# Altium schematic sheet 02)Microcontroller.SchDoc(02)Microcontroller)
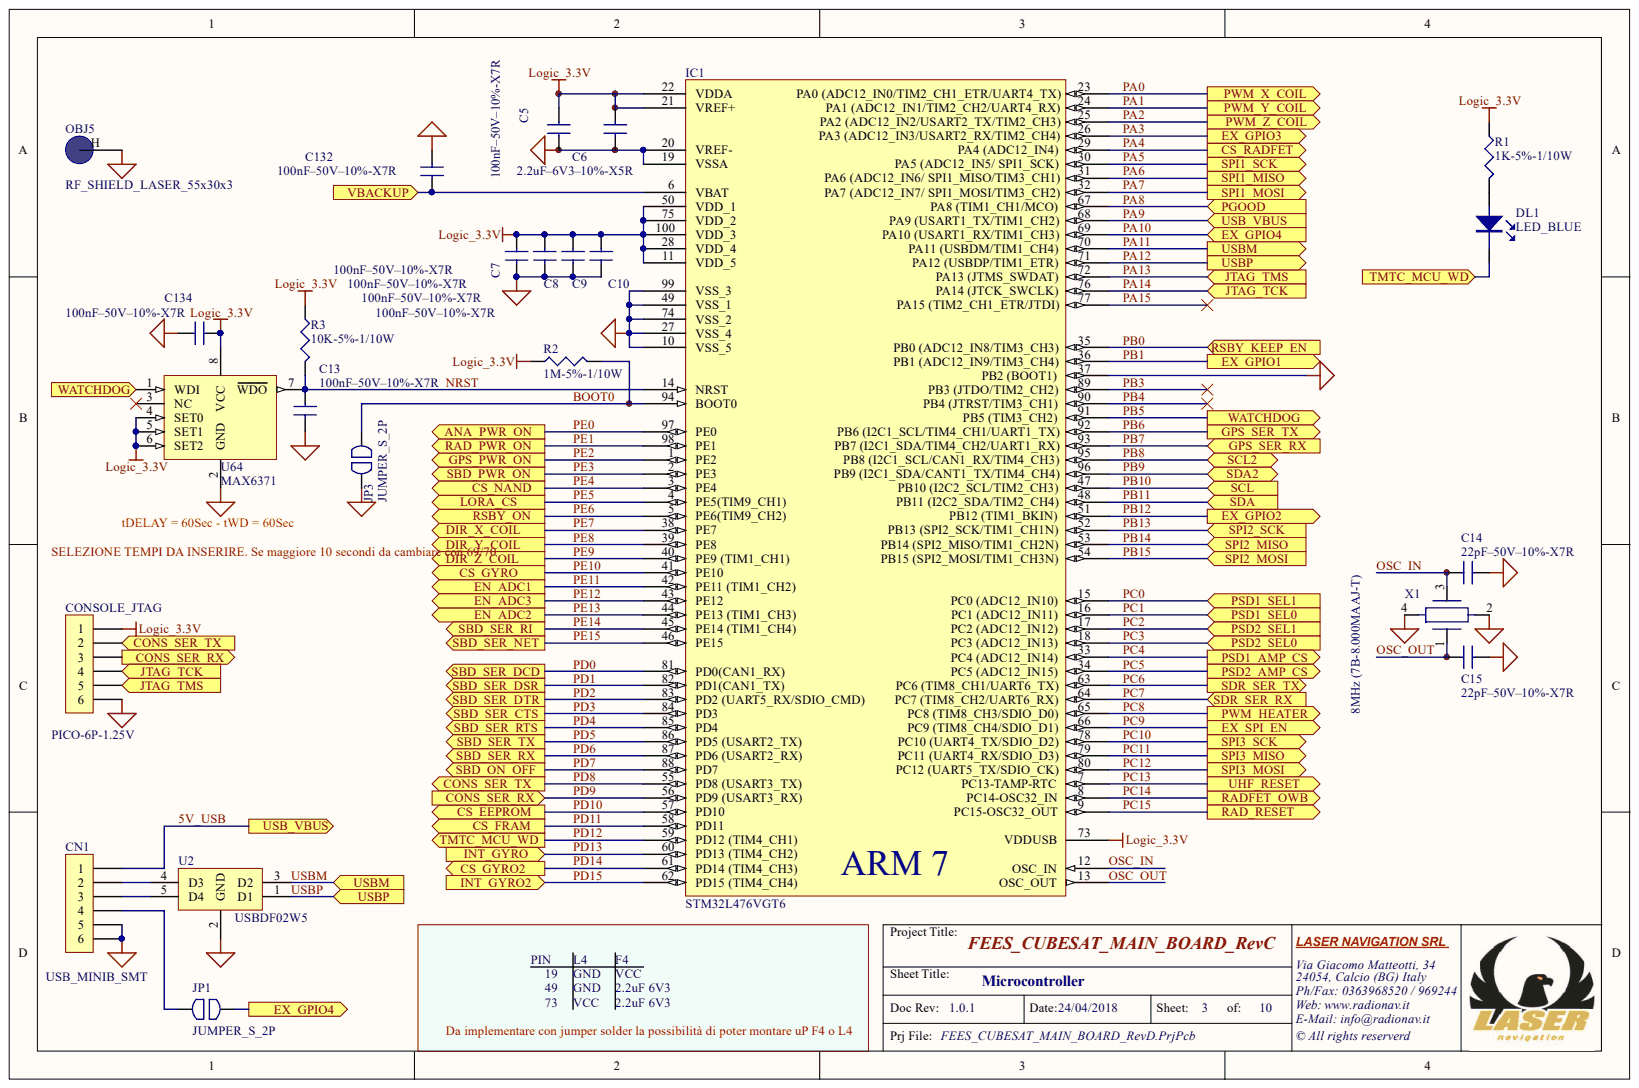
[diagram p0: 02)Microcontroller.SchDoc]

## Parts
C5  100nF–50V–10%-X7R  [0603_CAP]
C6  2.2uF–6V3–10%-X5R  [0603_CAP]
C7  100nF–50V–10%-X7R  [0603_CAP]
C8  100nF–50V–10%-X7R  [0603_CAP]
C9  100nF–50V–10%-X7R  [0603_CAP]
C10  100nF–50V–10%-X7R  [0603_CAP]
C13  100nF–50V–10%-X7R  [0603_CAP]
C14  22pF–50V–10%-X7R  [0603_CAP]
C15  22pF–50V–10%-X7R  [0603_CAP]
C132  100nF–50V–10%-X7R  [0603_CAP]
C134  100nF–50V–10%-X7R  [0603_CAP]
CN1  USB_MINIB_SMT  [USB_MINIB_SMT_PIN]
CONSOLE_JTAG  PICO-6P-1.25V  [PICO-6P-1.25V]
DL1  LED_BLUE  [0603_LED]
IC1  STM32L476VGT6  [TQFP-100]
JP1  JUMPER_S_2P  [JUMPER_SOLDER_0603]
JP3  JUMPER_S_2P  [JUMPER_SOLDER_0805]
OBJ5  RF_SHIELD_LASER_55x30x3  [RF_SHIELD_55x30x3]
R1  1K-5%-1/10W  [0603_RES]
R2  1M-5%-1/10W  [0603_RES]
R3  10K-5%-1/10W  [0603_RES]
U2  USBDF02W5  [SOT323-5]
U64  MAX6371  [SOT23-8]
X1  8MHz (7B-8.000MAAJ-T)  [XTAL-SMT-5x3.2]

## Ports
ANA_PWR_ON, CONS_SER_RX, CONS_SER_TX, CS_EEPROM, CS_FRAM, CS_GYRO, CS_GYRO2, CS_NAND, CS_RADFET, DIR_X_COIL, DIR_Y_COIL, DIR_Z_COIL, EN_ADC1, EN_ADC2, EN_ADC3, EX_GPIO1, EX_GPIO2, EX_GPIO3, EX_GPIO4, EX_SPI_EN, GPS_PWR_ON, GPS_SER_RX, GPS_SER_TX, INT_GYRO, INT_GYRO2, JTAG_TCK, JTAG_TMS, LORA_CS, PGOOD, PSD1_AMP_CS, PSD1_SEL0, PSD1_SEL1, PSD2_AMP_CS, PSD2_SEL0, PSD2_SEL1, PWM_HEATER, PWM_X_COIL, PWM_Y_COIL, PWM_Z_COIL, RAD_PWR_ON, RAD_RESET, RADFET_OWB, RSBY_KEEP_EN, RSBY_ON, SBD_ON_OFF, SBD_PWR_ON, SBD_SER_CTS, SBD_SER_DCD, SBD_SER_DSR, SBD_SER_DTR, SBD_SER_NET, SBD_SER_RI, SBD_SER_RTS, SBD_SER_RX, SBD_SER_TX, SCL, SCL2, SDA, SDA2, SDR_SER_RX, SDR_SER_TX, SPI1_MISO, SPI1_MOSI, SPI1_SCK, SPI2_MISO, SPI2_MOSI, SPI2_SCK, SPI3_MISO, SPI3_MOSI, SPI3_SCK, TMTC_MCU_WD, UHF_RESET, USB_VBUS, USBM, USBP, VBACKUP, WATCHDOG

## Nets
GND: C5.2 C6.2 C7.2 C8.2 C9.2 C10.2 C13.1 C14.2 C15.2 C132.2 C134.1 CN1.5 CN1.6 CONSOLE_JTAG.6 IC1.10(VSS_5) IC1.19(VSSA) IC1.20(VREF-) IC1.27(VSS_4) IC1.37(PB2_(BOOT1)) IC1.49(VSS_1) IC1.74(VSS_2) IC1.99(VSS_3) JP3.2 OBJ5.H U2.2(GND) U64.2(GND) X1.2 X1.4
LOGIC_3.3V: C5.1 C6.1 C7.1 C8.1 C9.1 C10.1 C134.2 CONSOLE_JTAG.1 IC1.11(VDD_5) IC1.21(VREF+) IC1.22(VDDA) IC1.28(VDD_4) IC1.50(VDD_1) IC1.73(VDDUSB) IC1.75(VDD_2) IC1.100(VDD_3) R1.1 R2.1 R3.1 U64.4(SET0) U64.5(SET1) U64.6(SET2) U64.8(VCC)
5V_USB: CN1.1 IC1.68(PA9_(USART1_TX/TIM1_CH2)) ; port USB_VBUS
BOOT0: IC1.94(BOOT0) JP3.1 R2.2
NRST: C13.2 IC1.14(NRST) R3.2 U64.7(WDO)
OSC_IN: C14.1 IC1.12(OSC_IN) X1.3
OSC_OUT: C15.1 IC1.13(OSC_OUT) X1.1
PA0: IC1.23 ; port PWM_X_COIL
PA1: IC1.24 ; port PWM_Y_COIL
PA2: IC1.25(PA2_(ADC12_IN2/USART2_TX/TIM2_CH3)) ; port PWM_Z_COIL
PA3: IC1.26(PA3_(ADC12_IN3/USART2_RX/TIM2_CH4)) ; port EX_GPIO3
PA4: IC1.29(PA4_(ADC12_IN4)) ; port CS_RADFET
PA5: IC1.30(PA5_(ADC12_IN5/_SPI1_SCK)) ; port SPI1_SCK
PA6: IC1.31(PA6_(ADC12_IN6/_SPI1_MISO/TIM3_CH1)) ; port SPI1_MISO
PA7: IC1.32 ; port SPI1_MOSI
PA8: IC1.67(PA8_(TIM1_CH1/MCO)) ; port PGOOD
PA10: IC1.69(PA10_(USART1_RX/TIM1_CH3)) JP1.2 ; port EX_GPIO4
PA11: IC1.70(PA11_(USBDM/TIM1_CH4)) U2.3(D2) ; port USBM
PA12: IC1.71(PA12_(USBDP/TIM1_ETR)) U2.1(D1) ; port USBP
PA13: CONSOLE_JTAG.5 IC1.72(PA13_(JTMS_SWDAT)) ; port JTAG_TMS
PA14: CONSOLE_JTAG.4 IC1.76(PA14_(JTCK_SWCLK)) ; port JTAG_TCK
PA15: IC1.77(PA15_(TIM2_CH1_ETR/JTDI))
PB0: IC1.35(PB0_(ADC12_IN8/TIM3_CH3)) ; port RSBY_KEEP_EN
PB1: IC1.36(PB1_(ADC12_IN9/TIM3_CH4)) ; port EX_GPIO1
PB3: IC1.89(PB3_(JTDO/TIM2_CH2))
PB4: IC1.90(PB4_(JTRST/TIM3_CH1))
PB5: IC1.91(PB5_(TIM3_CH2)) U64.1(WDI) ; port WATCHDOG
PB6: IC1.92 ; port GPS_SER_TX
PB7: IC1.93 ; port GPS_SER_RX
PB8: IC1.95 ; port SCL2
PB9: IC1.96 ; port SDA2
PB10: IC1.47(PB10_(I2C2_SCL/TIM2_CH3)) ; port SCL
PB11: IC1.48(PB11_(I2C2_SDA/TIM2_CH4)) ; port SDA
PB12: IC1.51(PB12_(TIM1_BKIN)) ; port EX_GPIO2
PB13: IC1.52(PB13_(SPI2_SCK/TIM1_CH1N)) ; port SPI2_SCK
PB14: IC1.53(PB14_(SPI2_MISO/TIM1_CH2N)) ; port SPI2_MISO
PB15: IC1.54(PB15_(SPI2_MOSI/TIM1_CH3N)) ; port SPI2_MOSI
PC0: IC1.15(PC0_(ADC12_IN10)) ; port PSD1_SEL1
PC1: IC1.16(PC1_(ADC12_IN11)) ; port PSD1_SEL0
PC2: IC1.17(PC2_(ADC12_IN12)) ; port PSD2_SEL1
PC3: IC1.18(PC3_(ADC12_IN13)) ; port PSD2_SEL0
PC4: IC1.33(PC4_(ADC12_IN14)) ; port PSD1_AMP_CS
PC5: IC1.34(PC5_(ADC12_IN15)) ; port PSD2_AMP_CS
PC6: IC1.63(PC6_(TIM8_CH1/UART6_TX)) ; port SDR_SER_TX
PC7: IC1.64(PC7_(TIM8_CH2/UART6_RX)) ; port SDR_SER_RX
PC8: IC1.65(PC8_(TIM8_CH3/SDIO_D0)) ; port PWM_HEATER
PC9: IC1.66(PC9_(TIM8_CH4/SDIO_D1)) ; port EX_SPI_EN
PC10: IC1.78(PC10_(UART4_TX/SDIO_D2)) ; port SPI3_SCK
PC11: IC1.79(PC11_(UART4_RX/SDIO_D3)) ; port SPI3_MISO
PC12: IC1.80(PC12_(UART5_TX/SDIO_CK)) ; port SPI3_MOSI
PC13: IC1.7(PC13-TAMP-RTC) ; port UHF_RESET
PC14: IC1.8(PC14-OSC32_IN) ; port RADFET_OWB
PC15: IC1.9(PC15-OSC32_OUT) ; port RAD_RESET
PD0: IC1.81(PD0(CAN1_RX)) ; port SBD_SER_DCD
PD1: IC1.82(PD1(CAN1_TX)) ; port SBD_SER_DSR
PD2: IC1.83(PD2_(UART5_RX/SDIO_CMD)) ; port SBD_SER_DTR
PD3: IC1.84(PD3) ; port SBD_SER_CTS
PD4: IC1.85(PD4) ; port SBD_SER_RTS
PD5: IC1.86(PD5_(USART2_TX)) ; port SBD_SER_TX
PD6: IC1.87(PD6_(USART2_RX)) ; port SBD_SER_RX
PD7: IC1.88(PD7) ; port SBD_ON_OFF
PD8: CONSOLE_JTAG.2 IC1.55(PD8_(USART3_TX)) ; port CONS_SER_TX
PD9: CONSOLE_JTAG.3 IC1.56(PD9_(USART3_RX)) ; port CONS_SER_RX
PD10: IC1.57(PD10) ; port CS_EEPROM
PD11: IC1.58(PD11) ; port CS_FRAM
PD12: DL1.2 IC1.59(PD12_(TIM4_CH1)) ; port TMTC_MCU_WD
PD13: IC1.60(PD13_(TIM4_CH2)) ; port INT_GYRO
PD14: IC1.61(PD14_(TIM4_CH3)) ; port CS_GYRO2
PD15: IC1.62(PD15_(TIM4_CH4)) ; port INT_GYRO2
PE0: IC1.97(PE0) ; port ANA_PWR_ON
PE1: IC1.98(PE1) ; port RAD_PWR_ON
PE2: IC1.1(PE2) ; port GPS_PWR_ON
PE3: IC1.2(PE3) ; port SBD_PWR_ON
PE4: IC1.3(PE4) ; port CS_NAND
PE5: IC1.4(PE5(TIM9_CH1)) ; port LORA_CS
PE6: IC1.5(PE6(TIM9_CH2)) ; port RSBY_ON
PE7: IC1.38(PE7) ; port DIR_X_COIL
PE8: IC1.39(PE8) ; port DIR_Y_COIL
PE9: IC1.40(PE9_(TIM1_CH1)) ; port DIR_Z_COIL
PE10: IC1.41(PE10) ; port CS_GYRO
PE11: IC1.42(PE11_(TIM1_CH2)) ; port EN_ADC1
PE12: IC1.43(PE12) ; port EN_ADC3
PE13: IC1.44(PE13_(TIM1_CH3)) ; port EN_ADC2
PE14: IC1.45(PE14_(TIM1_CH4)) ; port SBD_SER_RI
PE15: IC1.46(PE15) ; port SBD_SER_NET
VBACKUP: C132.1 IC1.6(VBAT) ; port VBACKUP
NetCN1_2: CN1.2 U2.4(D3)
NetCN1_3: CN1.3 U2.5(D4)
NetCN1_4: CN1.4 JP1.1
NetDL1_1: DL1.1 R1.2

Not connected: U64 3(NC)

## Library data of the parts
C5 C7-C10 C13-C15 C132 C134 = CAP: Non polarized capacitor; Component Kind: Standard; Library Name: User Sch Library.SchLib
C6 = CAP: Non polarized capacitor; 1.Part Number: JMK107BJ225KAHT; 2.Manufacturer: Taiyo Yuden; 3.Description: CAP CER 2.2UF 6.3V X5R 0603; 5.Mounting: Y; 4.Supplier: Digikey 587-3386-1-ND; Component Kind: Standard; Library Name: User Sch Library.SchLib
CN1 = CON6: Connector; 1.Part Number: 65100516121; 2.Manufacturer: Wurth Elektronik; 3.Description: USB miniB SMT Horizontal; 5.Mounting: Y; 4.Supplier: Wurth Elektronik // Mouser 710-65100516121; Component Kind: Standard; Library Name: User Sch Library.SchLib
CONSOLE_JTAG = CON6: Connector; 1.Part Number: 53398-0671; 2.Manufacturer: Molex, LLC; 3.Description: CONN HEADER 6POS 1.25MM VERT SMD; 5.Mounting: Y; 4.Supplier: Digikey WM7610CT-ND; Component Kind: Standard; Library Name: User Sch Library.SchLib
DL1 = LED: Light Emitting Diode; 1.Part Number: LB Q39G-L2N2-35-1; 2.Manufacturer: Osram; 3.Description: LED BLUE DIFFUSED 0603 SMD; 5.Mounting: Y; 4.Supplier: Mouser 720-LBQ39GL2N2351; Component Kind: Standard; Library Name: User Sch Library.SchLib
IC1 = STM32L486VGT6: IC MCU 32BIT 1MB FLASH 100LQFP; 2.Manufacturer: STMicroelectronics; 3.Description: ARM® Cortex®-M4 STM32L4 Microcontroller IC 32-Bit 80MHz 1MB (1M x 8) FLASH 100-LQFP (14x14); 5.Mounting: Y; 1.Part Number: STM32L476VGT6; 4.Supplier: RS  896-7699; Component Kind: Standard; Library Name: User Sch Library.SchLib
JP1 JP3 = JUMPER_S_2P: 5.Mounting: not mounted; Component Kind: Standard; Library Name: User Sch Library.SchLib
OBJ5 = OBJET_SHIELD: OBJET SHIELD; 1.Part Number: RF_SHIED_LASER_55x30x3; 2.Manufacturer: Linkit; 3.Description: Rf shield; 5.Mounting: Y; 4.Supplier: Linkit; Component Kind: Standard; Library Name: User Sch Library.SchLib
R1 R2 = R: Component Kind: Standard; Library Name: User Sch Library.SchLib
R3 = R: 5.Mounting: Y; Component Kind: Standard; Library Name: User Sch Library.SchLib
U2 = USBDFXXW5: USB EMI FILTER; 2.Manufacturer: STMicroelectronics; 3.Description: IC EMI FILTER/LINE TERM SOT323-5; 5.Mounting: Y; 1.Part Number: USBDF02W5; 4.Supplier: Mouser 511-USBDF02W5 // RS 250-628P // Digikey  497-3742-1-ND; Component Kind: Standard; Library Name: User Sch Library.SchLib
U64 = MAX6371: Series Simple Switcher Step-Up Voltage Regulator; 1.Part Number: MAX6371KA+T; 2.Manufacturer: Maxim Integrated; 3.Description: IC TIMER WATCHDOG SOT23-8; 5.Mounting: Y; 4.Supplier: Digikey  	MAX6371KA+TCT-ND; Component Kind: Standard; Library Name: User Sch Library.SchLib
X1 = XTAL-SMT-4PIN: Piezoelectric Crystal with Holder (Motional Inductance); 2.Manufacturer: TXC CORPORATION; 3.Description: Crystal 8.0000MHz 30ppm 18pF 100 Ohm -20°C - 70°C; 5.Mounting: Y; 1.Part Number: 7B-8.000MAAJ-T; 4.Supplier: Digi-Key 887-1452-1-ND // Farnell: 1892154 // Mouser  717-7B-8.000MAAJ-T; Component Kind: Standard; Library Name: User Sch Library.SchLib
